# Altium schematic sheet AudioBoardAmp.SchDoc
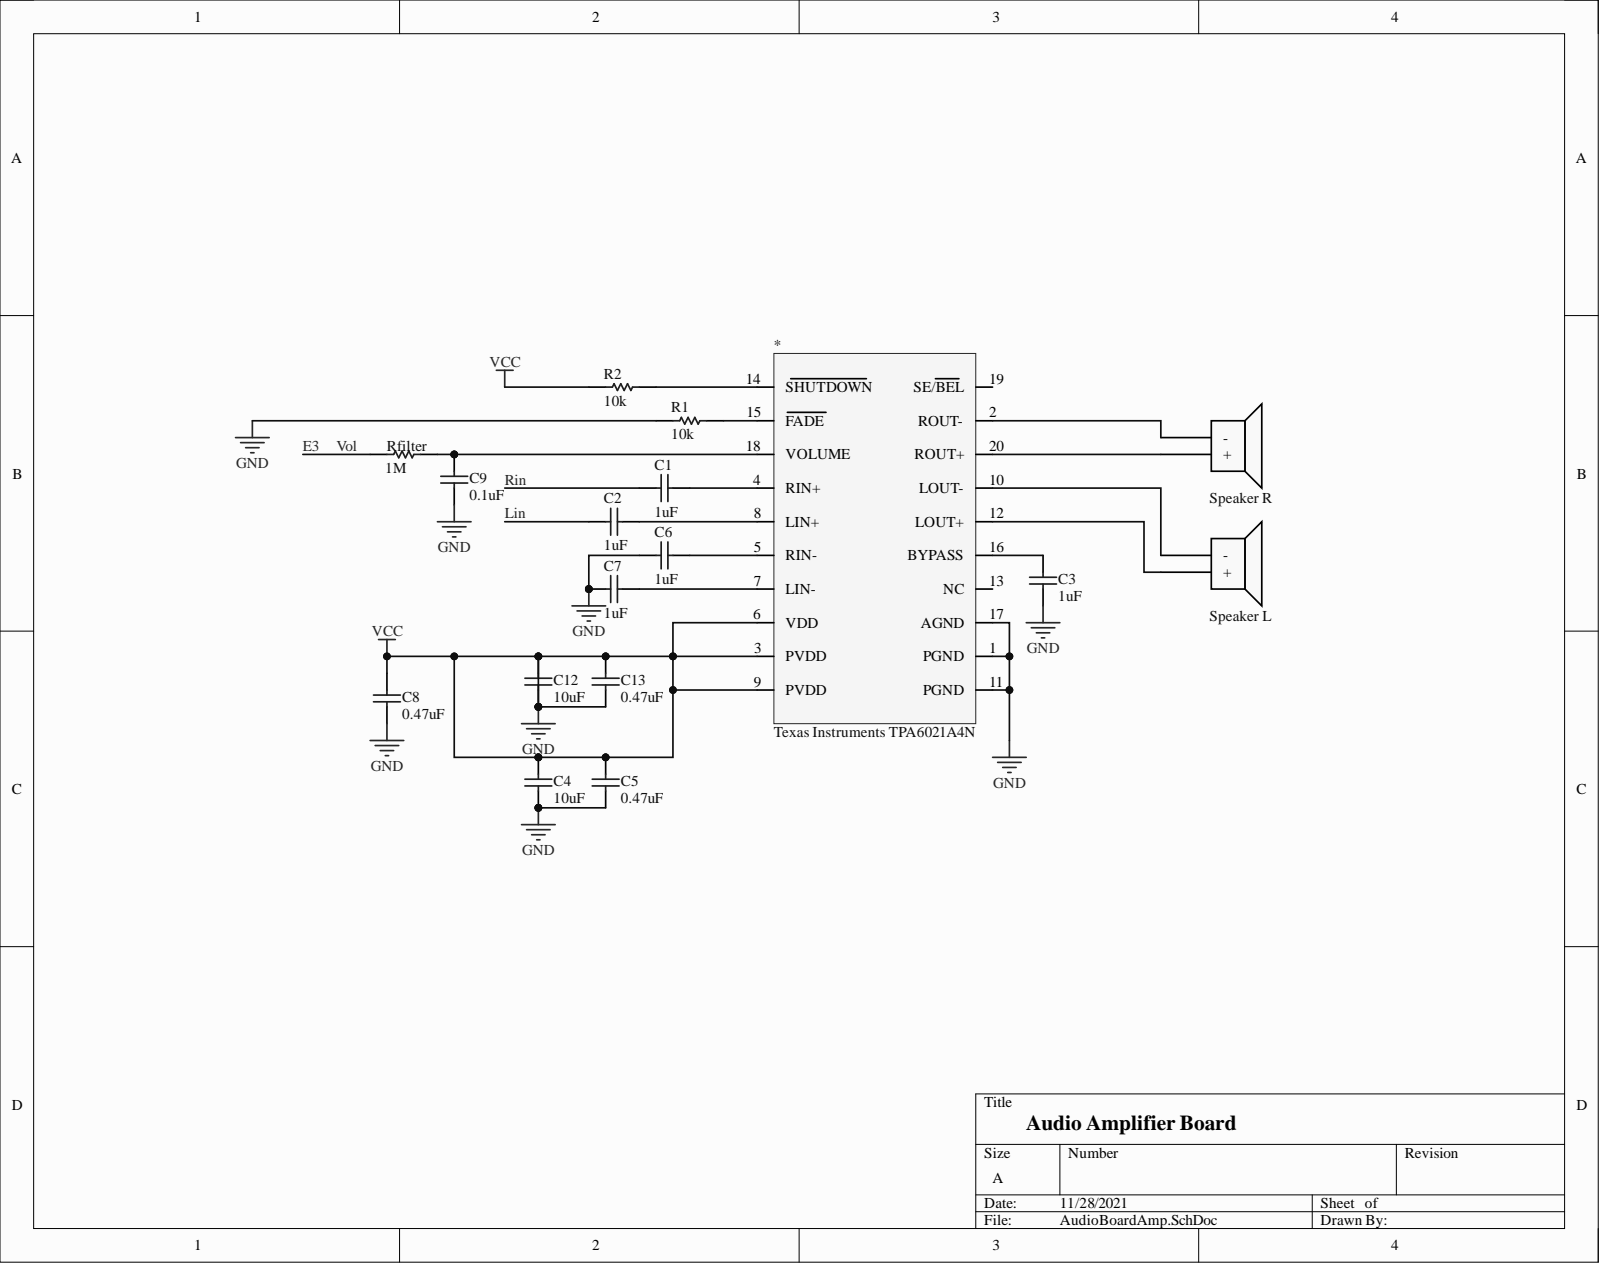
[diagram p0: AudioBoardAmp.SchDoc]

## Parts
*  Texas Instruments TPA6021A4N  Manufacturer Part Number: TPA6021A4N
C1  1uF  [PCB-414doup3lqi1tfwm7xsm-1]
C2  1uF  [PCB-414doup3lqi1tfwm7xsm-1]
C3  1uF  [PCB-414doup3lqi1tfwm7xsm-1]
C4  10uF  [PCB-414doup3lqi1tfwm7xsm-1]
C5  0.47uF  [PCB-414doup3lqi1tfwm7xsm-1]
C6  1uF  [PCB-414doup3lqi1tfwm7xsm-1]
C7  1uF  [PCB-414doup3lqi1tfwm7xsm-1]
C8  0.47uF  [PCB-414doup3lqi1tfwm7xsm-1]
C9  0.1uF  [PCB-414doup3lqi1tfwm7xsm-1]
C12  10uF  [PCB-414doup3lqi1tfwm7xsm-1]
C13  0.47uF  [PCB-414doup3lqi1tfwm7xsm-1]
R1  10k  [PCB-q466dezs08uszq8774n0-1]
R2  10k  [PCB-q466dezs08uszq8774n0-1]
Rfilter  1M  [PCB-q466dezs08uszq8774n0-1]
Speaker_L  CUI CDS-27204-L100  [PCB-fhipf8qrfds9dci2ah7a-1]  (value not drawn)
Speaker_R  CUI CDS-27204-L100  [PCB-fhipf8qrfds9dci2ah7a-1]  (value not drawn)

## Nets
GND: *.1(PGND) *.3(PVDD) *.6(VDD) *.9(PVDD) *.11(PGND) *.17(AGND) C3.2 C4.1 C4.2 C5.1 C5.2 C6.2 C7.2 C8.1 C8.2 C9.2 C12.1 C12.2 C13.1 C13.2 R1.1 R2.1
E3: Rfilter.1
Lin: C2.2
Rin: C1.2
Net*_2: *.2(ROUT-) Speaker_R.2
Net*_4: *.4(RIN+) C1.1
Net*_5: *.5(RIN-) C6.1
Net*_7: *.7(LIN-) C7.1
Net*_8: *.8(LIN+) C2.1
Net*_10: *.10(LOUT-) Speaker_L.2
Net*_12: *.12(LOUT+) Speaker_L.1
Net*_14: *.14(SHUTDOWN) R2.2
Net*_15: *.15(FADE) R1.2
Net*_16: *.16(BYPASS) C3.1
Net*_18: *.18(VOLUME) C9.1 Rfilter.2
Net*_20: *.20(ROUT+) Speaker_R.1

Not connected: * 13(NC) 19(SE/BEL)

## Library data of the parts
* = CMP-afca66c6b22b700d-1: Stereo, Class-AB Audio Amplifier with Volume Control and Stereo Headphone Drive 20-PDIP -40 to 85; Lead-Free Status: Lead Free; Number of Channels: 2; Output Power: 2.00 W; Quiescent Current: 1.00 µA; Supply Voltage (DC): 5.50 V; Case/Package: PDIP; Component Kind: Standard; Library Name: My Personal Space; Lifecycle Status: Active; Mounting Style: Through Hole; Number of Pins: 20; Octopart URL: http://octopart.com/tpa6021a4n-texas+instruments-407687; OctopartID: afca66c6b22b700d; Packaging: Tube; REACH SVHC Compliance: No SVHC; RoHS: Compliant
C1-C9 C12 C13 = CMP-a01d69675d8a7fda-13: Cap Ceramic 0.1uF 50V X7R 10% Radial 2.54mm 125°C Bulk; Component Kind: Standard; Library Name: My Personal Space
R1 R2 Rfilter = CMP-e82f23a0358237e8-1: RC Series 0201 0.05 W 1 kOhms 5% ±200 ppm/°C SMT Thick Film Chip Resistor; Manufacturer Part Number: RC0201JR-071KL; Lead-Free Status: Lead Free; Power Rating: 50.0 mW; Resistance: 1.00 kΩ; Voltage Rating (DC): 25.0 V; Case/Package: 0201; Component Kind: Standard; Library Name: My Personal Space; Mounting Style: Surface Mount; Number of Pins: 2; Octopart URL: http://octopart.com/rc0201jr-071kl-yageo-42334411; OctopartID: e82f23a0358237e8; Packaging: Tape & Reel; REACH SVHC Compliance: No SVHC; Resistance Tolerance: ±5%; RoHS: Compliant; Size-Length: 600 µm
Speaker_L Speaker_R = CMP-b2a97808e1e46d6e-5: 27 x 20 x 5.9 mm 2 W 4 O 850 Hz Nd-Fe-B High SPL Cloth Cone Wire Lead Speaker; Lead-Free Status: Lead Free; Component Kind: Standard; Library Name: My Personal Space; Lifecycle Status: Active; Manufacturer Part Number: CDS-27204-L100; Octopart URL: http://octopart.com/cds-27204-l100-cui-61568555; OctopartID: b2a97808e1e46d6e; RoHS: Compliant
